# Altium schematic sheet 原理图2.SchDoc(原理图2)
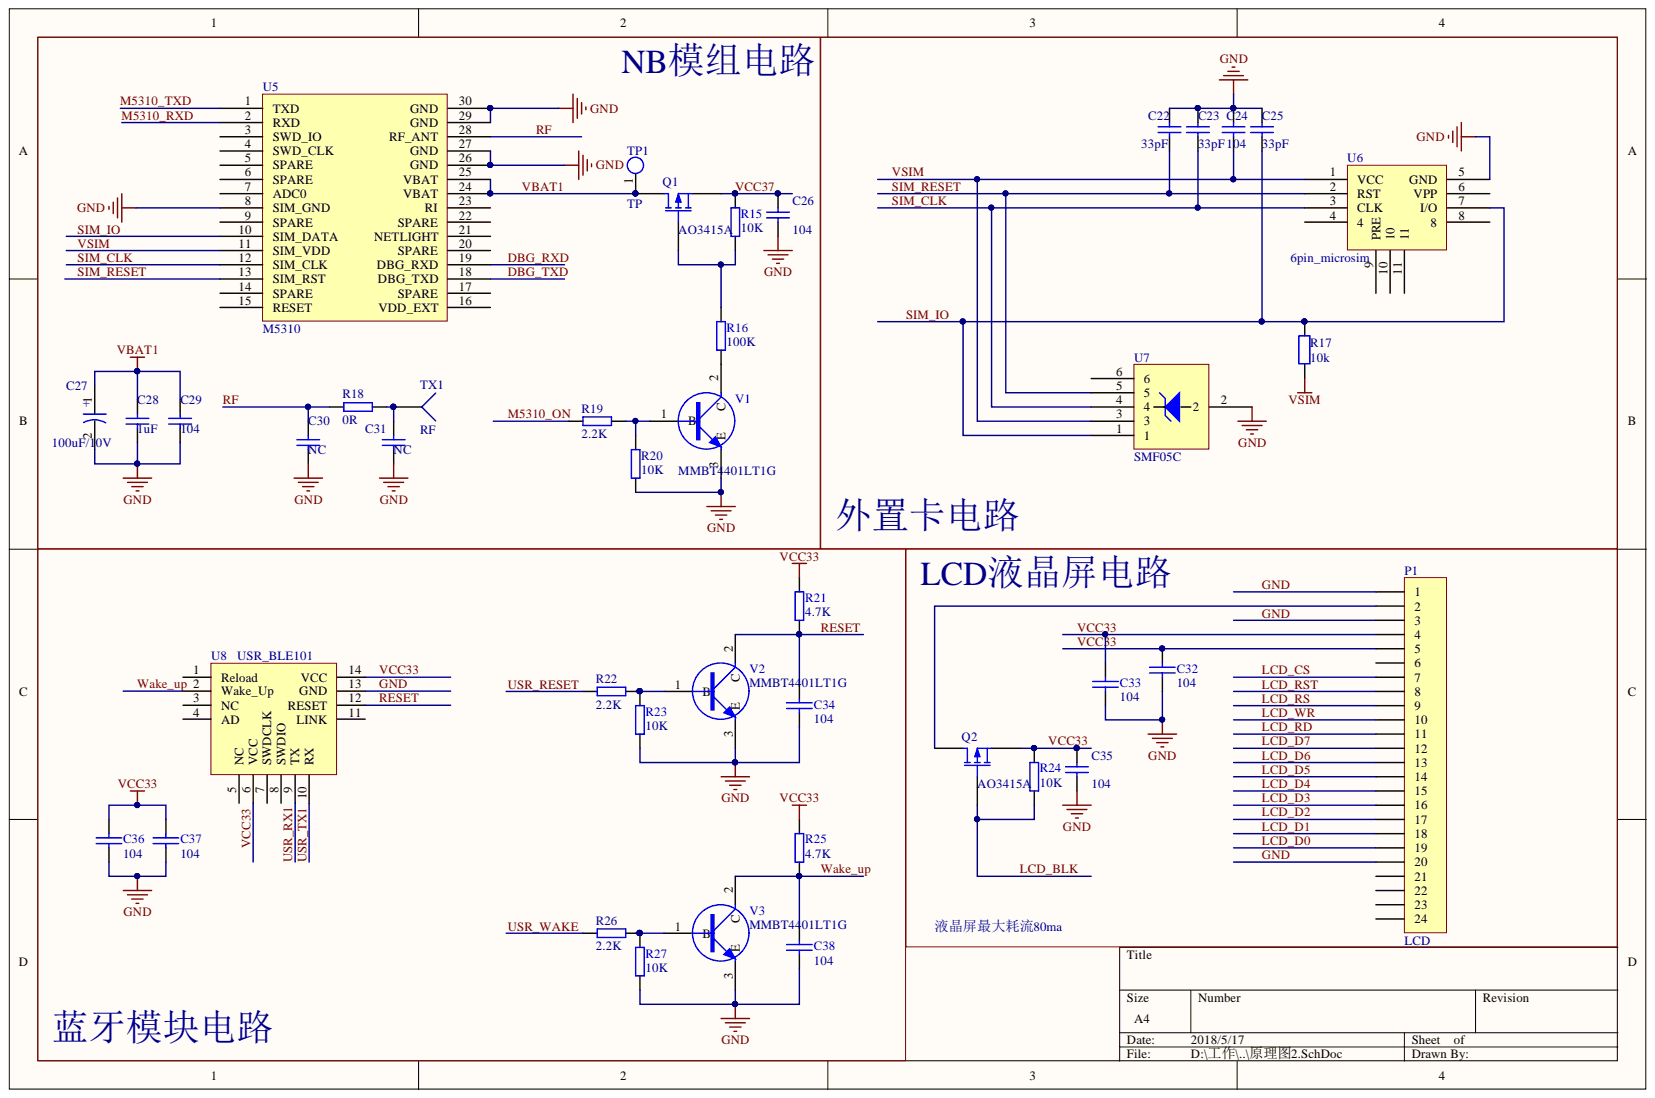
[diagram p0: 原理图2.SchDoc]

## Parts
C22  33pF  [0402C]
C23  33pF  [0402C]
C24  104  [0402C]
C25  33pF  [0402C]
C26  104  [0402C]
C27  100uF/10V  [LC-CASE-B_3528]
C28  value 1uF  [0402C]  (hidden comment: 104)
C29  104  [0402C]
C30  nc  [0402C]
C31  nc  [0402C]
C32  104  [0402C]
C33  104  [0402C]
C34  104  [0402C]
C35  104  [0402C]
C36  104  [0402C]
C37  104  [0402C]
C38  104  [0402C]
P1  LCD  [LCD_24P]
Q1  AO3415A  [SOT23-3]
Q2  AO3415A  [SOT23-3]
R15  10K  [0402R]
R16  100K  [0402R]
R17  value 10k  [0402R]  (hidden comment: 22R)
R18  0R  [0402R]
R19  2.2K  [0402R]
R20  10K  [0402R]
R21  4.7K  [0402R]
R22  2.2K  [0402R]
R23  10K  [0402R]
R24  10K  [0402R]
R25  4.7K  [0402R]
R26  2.2K  [0402R]
R27  10K  [0402R]
TP1  TP  [TP]
TX1  RF  [IPEX_ANT]
U5  M5310  [M5310]
U6  6pin_microsim  [MICRO_SIM]
U7  SMF05C  [SOT23-LMT84A]
U8  USR_BLE101  [USR_BLE101]
V1  MMBT4401LT1G  [SOT23-3]
V2  MMBT4401LT1G  [SOT23-3]
V3  MMBT4401LT1G  [SOT23-3]

## Nets
DBG_RXD: U5.19(DBG_RXD)
DBG_TXD: U5.18(DBG_TXD)
GND: C22.1 C23.1 C24.1 C25.1 C26.2 C27.2 C28.2 C29.2 C30.2 C31.2 C32.1 C33.1 C34.1 C35.2 C36.1 C37.1 C38.1 P1.1 P1.3 P1.20 R20.2 R23.2 R27.2 U5.8(SIM_GND) U5.26(GND) U5.27(GND) U5.29(GND) U5.30(GND) U6.5(GND) U7.2 U8.13(GND) V1.3 V2.3 V3.3
LCD_BLK: Q2.2 R24.2
LCD_CS: P1.7
LCD_D0: P1.19
LCD_D1: P1.18
LCD_D2: P1.17
LCD_D3: P1.16
LCD_D4: P1.15
LCD_D5: P1.14
LCD_D6: P1.13
LCD_D7: P1.12
LCD_RD: P1.11
LCD_RS: P1.9
LCD_RST: P1.8
LCD_WR: P1.10
M5310_ON: R19.2
M5310_RXD: U5.2(RXD)
M5310_TXD: U5.1(TXD)
RESET: C34.2 R21.2 U8.12(RESET) V2.2
RF: C30.1 R18.2 U5.28(RF_ANT)
SIM_CLK: C23.2 U5.12(SIM_CLK) U6.3(CLK) U7.4
SIM_IO: C25.2 R17.2 U5.10(SIM_DATA) U6.7(I/O) U7.1
SIM_RESET: C22.2 U5.13(SIM_RST) U6.2(RST) U7.5
USR_RESET: R22.2
USR_RX1: U8.9(TX)
USR_TX1: U8.10(RX)
USR_WAKE: R26.2
VBAT1: C27.1 C28.1 C29.1 Q1.1 TP1.1 U5.24(VBAT) U5.25(VBAT)
VCC33: C32.2 C33.2 C35.1 C36.2 C37.2 P1.4 P1.5 Q2.3 R21.1 R24.1 R25.1 U8.6(VCC) U8.14(VCC)
VCC37: C26.1 Q1.3 R15.1
VSIM: C24.2 R17.1 U5.11(SIM_VDD) U6.1(VCC) U7.3
WAKE_UP: C38.2 R25.2 U8.2(Wake_Up) V3.2
NetC31_1: C31.1 R18.1 TX1.1
NetP1_2: P1.2 Q2.1
NetQ1_2: Q1.2 R15.2 R16.1
NetR16_2: R16.2 V1.2
NetR19_1: R19.1 R20.1 V1.1
NetR22_1: R22.1 R23.1 V2.1
NetR26_1: R26.1 R27.1 V3.1

Not connected: P1 6 21 22 23 24; U5 3(SWD_IO) 4(SWD_CLK) 5(SPARE) 6(SPARE) 7(ADC0) 9(SPARE) 14(SPARE) 15(RESET) 16(VDD_EXT) 17(SPARE) 20(SPARE) 21(NETLIGHT) 22(SPARE) 23(RI); U6 4 6(VPP) 8 9(PRE) 10 11; U7 6; U8 1(Reload) 3(NC) 4(AD) 5(NC) 7(SWDCLK) 8(SWDIO) 11(LINK)

## Library data of the parts
C22-C26 C28-C31 C35 = 0201: Component Kind: Standard
  C22 C23 C25: Value: 33pF
  C24 C26 C29 C35: Value: 104
  C30 C31: Value: NC
C27 = 02050003: 'TAJB107M010RNJ; Component Kind: Standard; Value: 100uF/10V
C32-C34 C36-C38 = DR: Component Kind: Standard; Library Name: ydlZXSCHTK.SCHLIB
P1 = Header 24: Header, 24-Pin; Component Kind: Standard; LatestRevisionDate: 17-Jul-2002; LatestRevisionNote: Re-released for DXP Platform.; Library Name: Miscellaneous Connectors.IntLib; Publisher: Altium Limited
Q1 Q2 = MOSFET-P: P-Channel MOSFET; Component Kind: Standard; LatestRevisionDate: 17-Jul-2002; LatestRevisionNote: Re-released for DXP Platform.; Library Name: Miscellaneous Devices.IntLib; PackageDescription: TO, Flat Index; 3 In-Line, Axial Leads; Body Dia. 4.6mm; Lead Dia. 0.5 mm (max); PackageReference: E3; PackageVersion: 1995/1996; Published: 8-Jun-2000; Publisher: Altium Limited
R15 R16 R18-R27 = RES1: 标准电阻; : 片电阻; Component Kind: Standard; Library Name: 电容电阻.SchLib
R17 = 0101: Component Kind: Standard
U6 = 9pin_simcard: Component Kind: Standard; Library Name: 参考设计库.SchLib
V1-V3 = NPN: 三极管; : 贴片封装; Component Kind: Standard
TP1 = TP: Component Kind: Standard; Library Name: 参考设计库.SchLib
TX1 = RF: Component Kind: Standard; Library Name: 参考设计库.SchLib
U5 = M5310: Component Kind: Standard; Library Name: 参考设计库.SchLib
U7 = SMF05C: Component Kind: Standard; Library Name: 分立元件.SCHLIB
U8 = USR_BLE101: Component Kind: Standard; Library Name: 参考设计库.SchLib
